# Altium schematic sheet cuihua_test.SchDoc
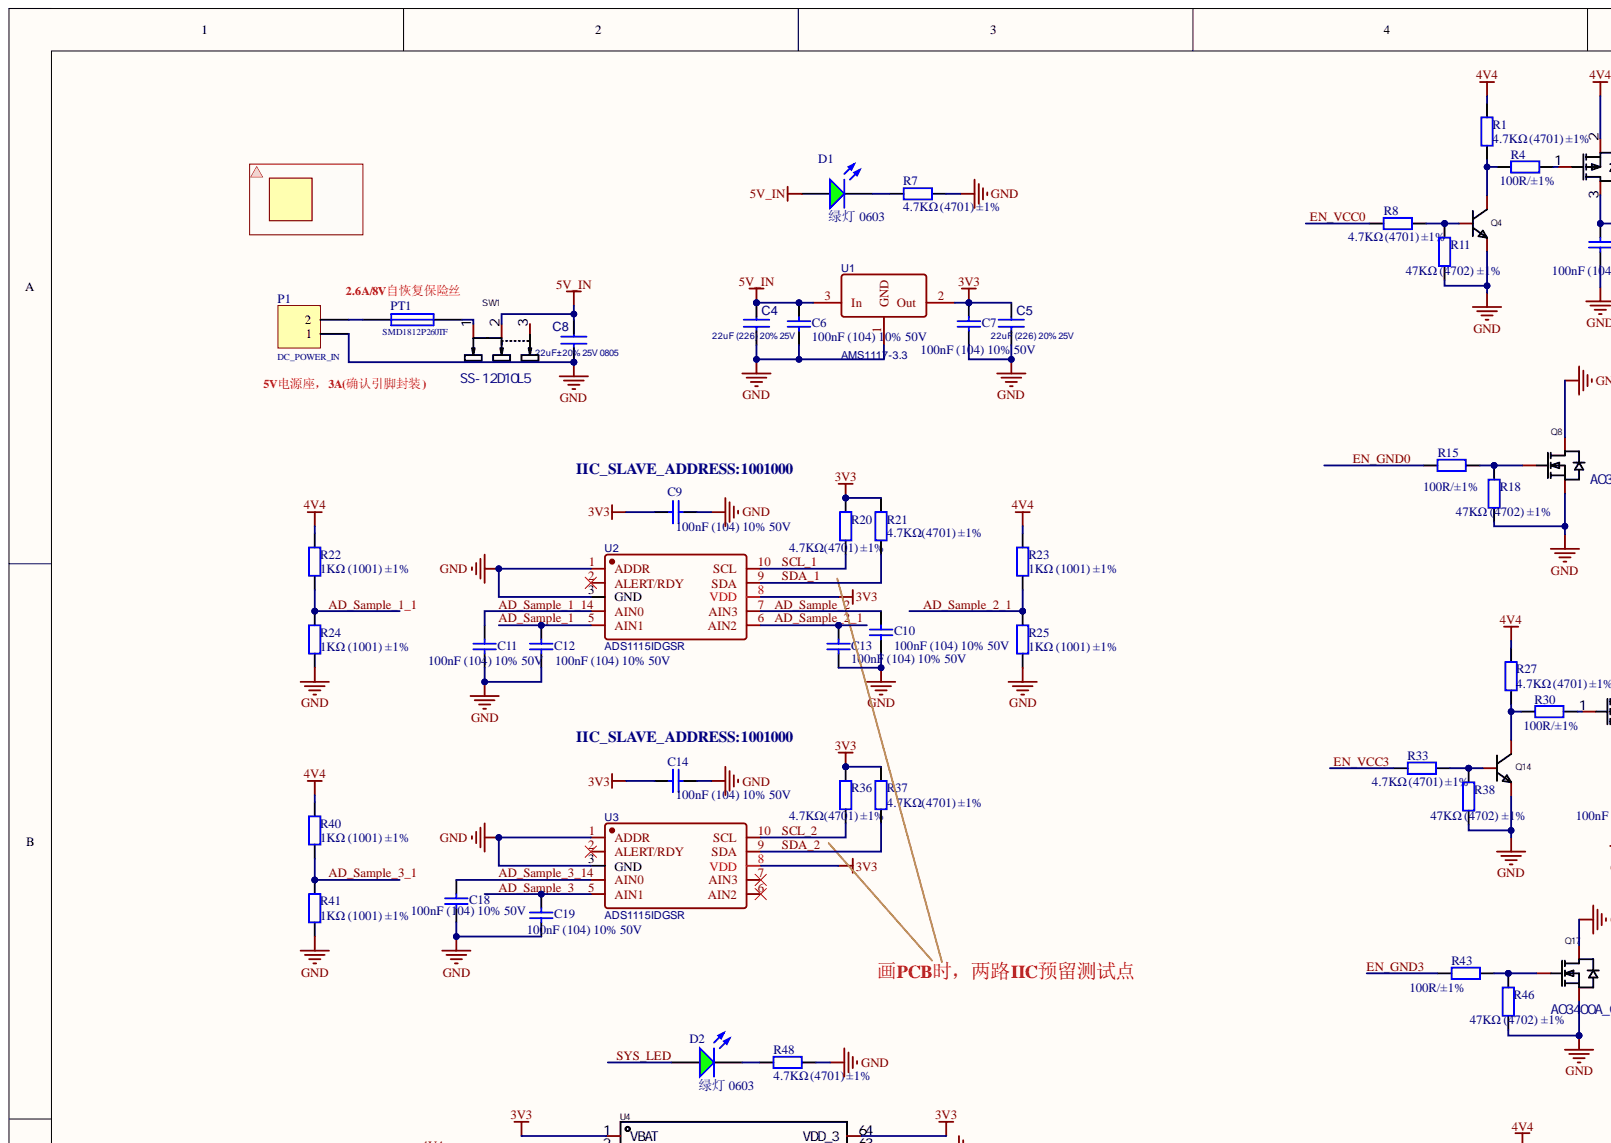
[diagram p0: cuihua_test.SchDoc, top left]
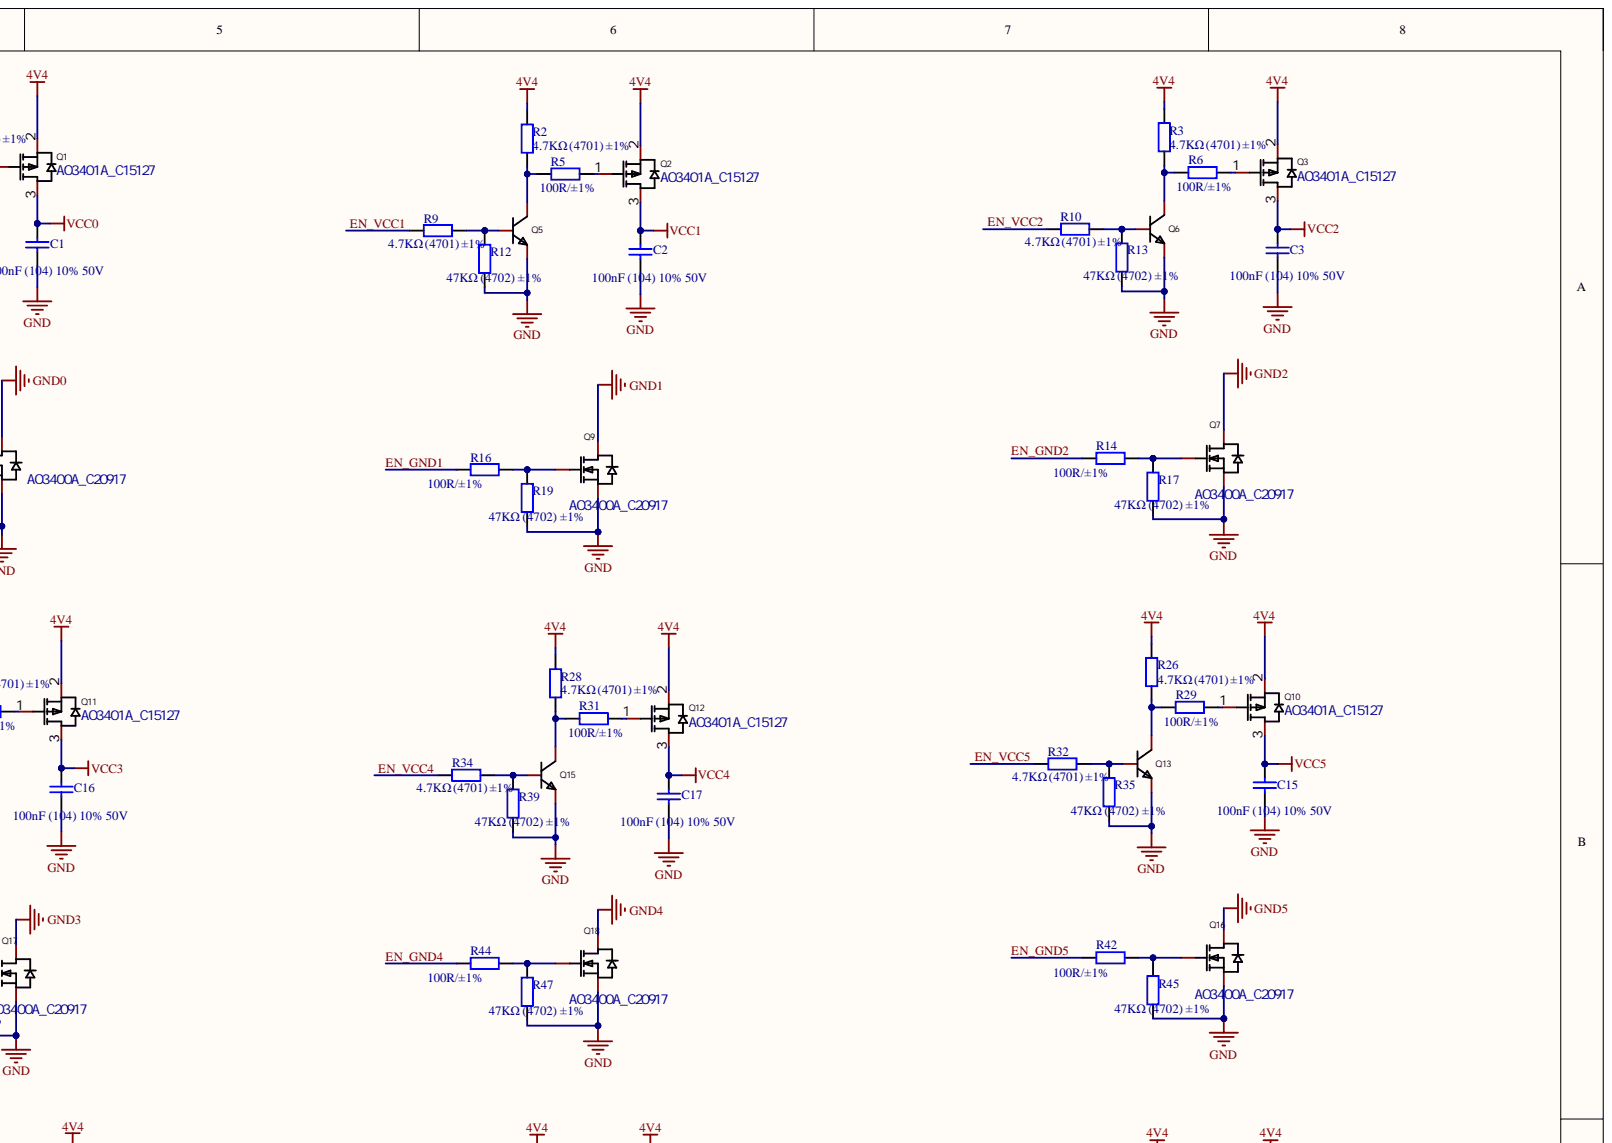
[diagram p1: cuihua_test.SchDoc, top right]
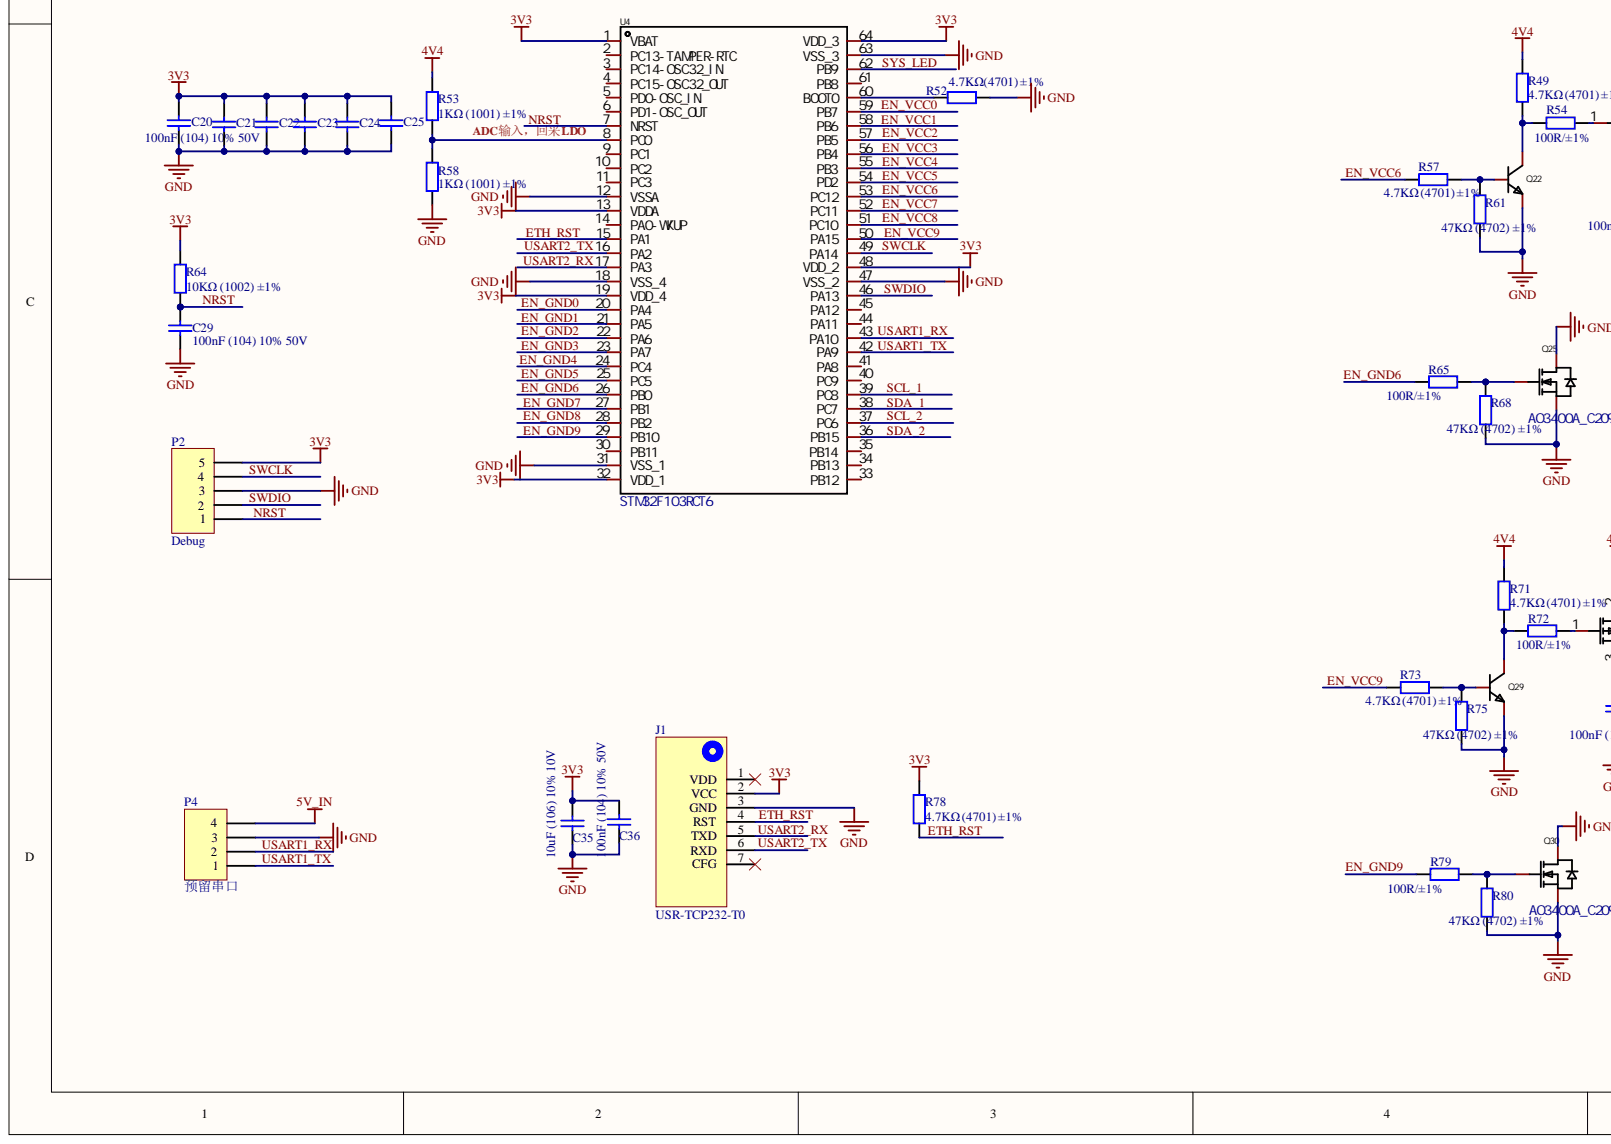
[diagram p2: cuihua_test.SchDoc, bottom left]
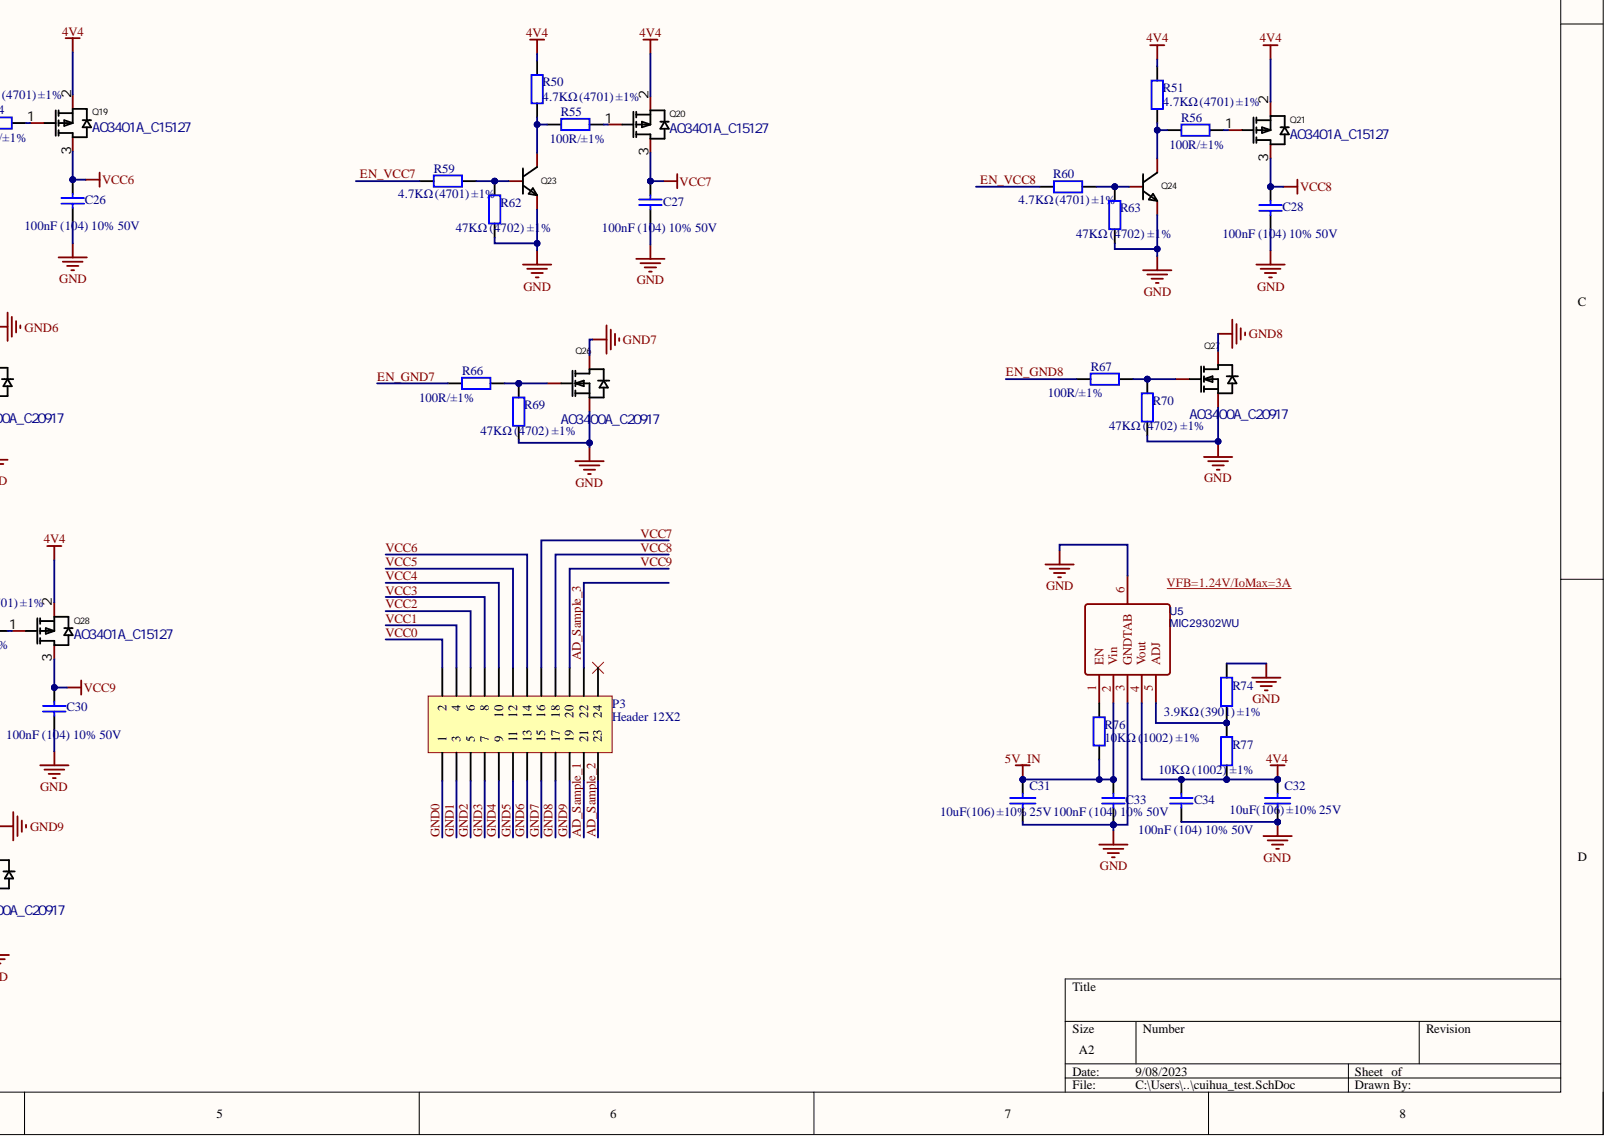
[diagram p3: cuihua_test.SchDoc, bottom right]

## Parts
C1  100nF (104) 10% 50V  [0603_C]
C2  100nF (104) 10% 50V  [0603_C]
C3  100nF (104) 10% 50V  [0603_C]
C4  22uF (226) 20% 25V  [0603_C]
C5  22uF (226) 20% 25V  [0603_C]
C6  100nF (104) 10% 50V  [0603_C]
C7  100nF (104) 10% 50V  [0603_C]
C8  22uF±20% 25V 0805  [0603_C]
C9  100nF (104) 10% 50V  [0603_C]
C10  100nF (104) 10% 50V  [0603_C]
C11  100nF (104) 10% 50V  [0603_C]
C12  100nF (104) 10% 50V  [0603_C]
C13  100nF (104) 10% 50V  [0603_C]
C14  100nF (104) 10% 50V  [0603_C]
C15  100nF (104) 10% 50V  [0603_C]
C16  100nF (104) 10% 50V  [0603_C]
C17  100nF (104) 10% 50V  [0603_C]
C18  100nF (104) 10% 50V  [0603_C]
C19  100nF (104) 10% 50V  [0603_C]
C20  100nF (104) 10% 50V  [0603_C]
C21  100nF (104) 10% 50V  [0603_C]
C22  100nF (104) 10% 50V  [0603_C]
C23  100nF (104) 10% 50V  [0603_C]
C24  100nF (104) 10% 50V  [0603_C]
C25  100nF (104) 10% 50V  [0603_C]
C26  100nF (104) 10% 50V  [0603_C]
C27  100nF (104) 10% 50V  [0603_C]
C28  100nF (104) 10% 50V  [0603_C]
C29  100nF (104) 10% 50V  [0603_C]
C30  100nF (104) 10% 50V  [0603_C]
C31  10uF(106) ±10% 25V  [0805_C]
C32  10uF(106) ±10% 25V  [0805_C]
C33  100nF (104) 10% 50V  [0603_C]
C34  100nF (104) 10% 50V  [0603_C]
C35  10uF (106) 10% 10V  [0603_C]
C36  100nF (104) 10% 50V  [0603_C]
D1  绿灯 0603  Package: 0603  [0603_LED]
D2  绿灯 0603  Package: 0603  [0603_LED]
J1  USR-TCP232-T0  [USR-TCP232-T0]
P1  DC_POWER_IN  [DC-005]
P2  Debug  [HDR1X5]
P3  Header 12X2  [Pin HDR2X12/2.54mm-S]
P4  预留串口  [HDR1X4]
PT1  SMD1812P260TF  [F1812]
Q1  AO3401A_C15127  [SOT-23-3L]
Q2  AO3401A_C15127  [SOT-23-3L]
Q3  AO3401A_C15127  [SOT-23-3L]
Q4  MMBT3904LT1G  [SOT-23-3L]  (value not drawn)
Q5  MMBT3904LT1G  [SOT-23-3L]  (value not drawn)
Q6  MMBT3904LT1G  [SOT-23-3L]  (value not drawn)
Q7  AO3400A_C20917  [SOT-23-3L]
Q8  AO3400A_C20917  [SOT-23-3L]
Q9  AO3400A_C20917  [SOT-23-3L]
Q10  AO3401A_C15127  [SOT-23-3L]
Q11  AO3401A_C15127  [SOT-23-3L]
Q12  AO3401A_C15127  [SOT-23-3L]
Q13  MMBT3904LT1G  [SOT-23-3L]  (value not drawn)
Q14  MMBT3904LT1G  [SOT-23-3L]  (value not drawn)
Q15  MMBT3904LT1G  [SOT-23-3L]  (value not drawn)
Q16  AO3400A_C20917  [SOT-23-3L]
Q17  AO3400A_C20917  [SOT-23-3L]
Q18  AO3400A_C20917  [SOT-23-3L]
Q19  AO3401A_C15127  [SOT-23-3L]
Q20  AO3401A_C15127  [SOT-23-3L]
Q21  AO3401A_C15127  [SOT-23-3L]
Q22  MMBT3904LT1G  [SOT-23-3L]  (value not drawn)
Q23  MMBT3904LT1G  [SOT-23-3L]  (value not drawn)
Q24  MMBT3904LT1G  [SOT-23-3L]  (value not drawn)
Q25  AO3400A_C20917  [SOT-23-3L]
Q26  AO3400A_C20917  [SOT-23-3L]
Q27  AO3400A_C20917  [SOT-23-3L]
Q28  AO3401A_C15127  [SOT-23-3L]
Q29  MMBT3904LT1G  [SOT-23-3L]  (value not drawn)
Q30  AO3400A_C20917  [SOT-23-3L]
R1  4.7KΩ (4701) ±1%  [0603_R]
R2  4.7KΩ (4701) ±1%  [0603_R]
R3  4.7KΩ (4701) ±1%  [0603_R]
R4  100R/±1%  [0603_R]
R5  100R/±1%  [0603_R]
R6  100R/±1%  [0603_R]
R7  4.7KΩ (4701) ±1%  [0603_R]
R8  4.7KΩ (4701) ±1%  [0603_R]
R9  4.7KΩ (4701) ±1%  [0603_R]
R10  4.7KΩ (4701) ±1%  [0603_R]
R11  47KΩ (4702) ±1%  [0603_R]
R12  47KΩ (4702) ±1%  [0603_R]
R13  47KΩ (4702) ±1%  [0603_R]
R14  100R/±1%  [0603_R]
R15  100R/±1%  [0603_R]
R16  100R/±1%  [0603_R]
R17  47KΩ (4702) ±1%  [0603_R]
R18  47KΩ (4702) ±1%  [0603_R]
R19  47KΩ (4702) ±1%  [0603_R]
R20  4.7KΩ(4701) ±1%  [0603_R]
R21  4.7KΩ(4701) ±1%  [0603_R]
R22  1KΩ (1001) ±1%  [0603_R]
R23  1KΩ (1001) ±1%  [0603_R]
R24  1KΩ (1001) ±1%  [0603_R]
R25  1KΩ (1001) ±1%  [0603_R]
R26  4.7KΩ (4701) ±1%  [0603_R]
R27  4.7KΩ (4701) ±1%  [0603_R]
R28  4.7KΩ (4701) ±1%  [0603_R]
R29  100R/±1%  [0603_R]
R30  100R/±1%  [0603_R]
R31  100R/±1%  [0603_R]
R32  4.7KΩ (4701) ±1%  [0603_R]
R33  4.7KΩ (4701) ±1%  [0603_R]
R34  4.7KΩ (4701) ±1%  [0603_R]
R35  47KΩ (4702) ±1%  [0603_R]
R36  4.7KΩ(4701) ±1%  [0603_R]
R37  4.7KΩ(4701) ±1%  [0603_R]
R38  47KΩ (4702) ±1%  [0603_R]
R39  47KΩ (4702) ±1%  [0603_R]
R40  1KΩ (1001) ±1%  [0603_R]
R41  1KΩ (1001) ±1%  [0603_R]
R42  100R/±1%  [0603_R]
R43  100R/±1%  [0603_R]
R44  100R/±1%  [0603_R]
R45  47KΩ (4702) ±1%  [0603_R]
R46  47KΩ (4702) ±1%  [0603_R]
R47  47KΩ (4702) ±1%  [0603_R]
R48  4.7KΩ (4701) ±1%  [0603_R]
R49  4.7KΩ (4701) ±1%  [0603_R]
R50  4.7KΩ (4701) ±1%  [0603_R]
R51  4.7KΩ (4701) ±1%  [0603_R]
R52  4.7KΩ(4701) ±1%  [0603_R]
R53  1KΩ (1001) ±1%  [0603_R]
R54  100R/±1%  [0603_R]
R55  100R/±1%  [0603_R]
R56  100R/±1%  [0603_R]
R57  4.7KΩ (4701) ±1%  [0603_R]
R58  1KΩ (1001) ±1%  [0603_R]
R59  4.7KΩ (4701) ±1%  [0603_R]
R60  4.7KΩ (4701) ±1%  [0603_R]
R61  47KΩ (4702) ±1%  [0603_R]
R62  47KΩ (4702) ±1%  [0603_R]
R63  47KΩ (4702) ±1%  [0603_R]
R64  10KΩ (1002) ±1%  [0603_R]
R65  100R/±1%  [0603_R]
R66  100R/±1%  [0603_R]
R67  100R/±1%  [0603_R]
R68  47KΩ (4702) ±1%  [0603_R]
R69  47KΩ (4702) ±1%  [0603_R]
R70  47KΩ (4702) ±1%  [0603_R]
R71  4.7KΩ (4701) ±1%  [0603_R]
R72  100R/±1%  [0603_R]
R73  4.7KΩ (4701) ±1%  [0603_R]
R74  3.9KΩ (3901) ±1%  [0603_R]
R75  47KΩ (4702) ±1%  [0603_R]
R76  10KΩ (1002) ±1%  [0603_R]
R77  10KΩ (1002) ±1%  [0603_R]
R78  4.7KΩ (4701) ±1%  [0603_R]
R79  100R/±1%  [0603_R]
R80  47KΩ (4702) ±1%  [0603_R]
SW1  SS-12D10L5  [SW-TH_SS-12D10L5]
U1  AMS1117-3.3  [SOT-223]
U2  ADS1115IDGSR  [MSOP-10]
U3  ADS1115IDGSR  [MSOP-10]
U4  STM32F103RCT6  [LQFP-64]
U5  MIC29302WU  [TO-263-5]

## Nets
3V3: C5.1 C7.2 C9.1 C14.1 C20.2 C21.2 C22.2 C23.2 C24.2 C25.2 C35.2 C36.2 J1.2(VCC) P2.5 R20.1 R21.2 R36.1 R37.2 R64.2 R78.1 U1.2 U2.8(VDD) U3.8(VDD) U4.1(VBAT) U4.13(VDDA) U4.19(VDD_4) U4.32(VDD_1) U4.48(VDD_2) U4.64(VDD_3)
4V4: C32.1 C34.1 Q1.2 Q2.2 Q3.2 Q10.2 Q11.2 Q12.2 Q19.2 Q20.2 Q21.2 Q28.2 R1.1 R2.1 R3.1 R22.2 R23.2 R26.1 R27.1 R28.1 R40.2 R49.1 R50.1 R51.1 R53.2 R71.1 R77.2 U5.4(Vout)
5V_IN: C4.1 C6.2 C8.1 C31.1 C33.1 D1.A P4.4 R76.1 SW1.2 U1.3 U5.2(Vin)
GND: C1.1 C2.1 C3.1 C4.2 C5.2 C6.1 C7.1 C8.2 C9.2 C10.1 C11.1 C12.1 C13.1 C14.2 C15.1 C16.1 C17.1 C18.1 C19.1 C20.1 C21.1 C22.1 C23.1 C24.1 C25.1 C26.1 C27.1 C28.1 C29.1 C30.1 C31.2 C32.2 C33.2 C34.2 C35.1 C36.1 J1.3(GND) P1.1 P2.3 P4.3 Q4.2 Q5.2 Q6.2 Q7.2 Q8.2 Q9.2 Q13.2 Q14.2 Q15.2 Q16.2 Q17.2 Q18.2 Q22.2 Q23.2 Q24.2 Q25.2 Q26.2 Q27.2 Q29.2 Q30.2 R7.1 R11.2 R12.2 R13.2 R17.2 R18.2 R19.2 R24.2 R25.2 R35.2 R38.2 R39.2 R41.2 R45.2 R46.2 R47.2 R48.1 R52.2 R58.2 R61.2 R62.2 R63.2 R68.2 R69.2 R70.2 R74.1 R75.2 R80.2 U1.1 U2.1(ADDR) U2.3(GND) U3.1(ADDR) U3.3(GND) U4.12(VSSA) U4.18(VSS_4) U4.31(VSS_1) U4.47(VSS_2) U4.63(VSS_3) U5.3(GND) U5.6(TAB)
AD_Sample_1: C12.2 P3.21 U2.5(AIN1)
AD_Sample_1_1: C11.2 R22.1 R24.1 U2.4(AIN0)
AD_Sample_2: C10.2 P3.23 U2.7(AIN3)
AD_Sample_2_1: C13.2 R23.1 R25.1 U2.6(AIN2)
AD_Sample_3: C19.2 P3.22 U3.5(AIN1)
AD_Sample_3_1: C18.2 R40.1 R41.1 U3.4(AIN0)
EN_GND0: R15.2 U4.20(PA4)
EN_GND1: R16.2 U4.21(PA5)
EN_GND2: R14.2 U4.22(PA6)
EN_GND3: R43.2 U4.23(PA7)
EN_GND4: R44.2 U4.24(PC4)
EN_GND5: R42.2 U4.25(PC5)
EN_GND6: R65.2 U4.26(PB0)
EN_GND7: R66.2 U4.27(PB1)
EN_GND8: R67.2 U4.28(PB2)
EN_GND9: R79.2 U4.29(PB10)
EN_VCC0: R8.2 U4.59(PB7)
EN_VCC1: R9.2 U4.58(PB6)
EN_VCC2: R10.2 U4.57(PB5)
EN_VCC3: R33.2 U4.56(PB4)
EN_VCC4: R34.2 U4.55(PB3)
EN_VCC5: R32.2 U4.54(PD2)
EN_VCC6: R57.2 U4.53(PC12)
EN_VCC7: R59.2 U4.52(PC11)
EN_VCC8: R60.2 U4.51(PC10)
EN_VCC9: R73.2 U4.50(PA15)
ETH_RST: J1.4(RST) R78.2 U4.15(PA1)
GND0: P3.1 Q8.3
GND1: P3.3 Q9.3
GND2: P3.5 Q7.3
GND3: P3.7 Q17.3
GND4: P3.9 Q18.3
GND5: P3.11 Q16.3
GND6: P3.13 Q25.3
GND7: P3.15 Q26.3
GND8: P3.17 Q27.3
GND9: P3.19 Q30.3
NRST: C29.2 P2.1 R64.1 U4.7(NRST)
SCL_1: R20.2 U2.10(SCL) U4.39(PC8)
SCL_2: R36.2 U3.10(SCL) U4.37(PC6)
SDA_1: R21.1 U2.9(SDA) U4.38(PC7)
SDA_2: R37.1 U3.9(SDA) U4.36(PB15)
SWCLK: P2.4 U4.49(PA14)
SWDIO: P2.2 U4.46(PA13)
SYS_LED: D2.A U4.62(PB9)
USART1_RX: P4.2 U4.43(PA10)
USART1_TX: P4.1 U4.42(PA9)
USART2_RX: J1.5(TXD) U4.17(PA3)
USART2_TX: J1.6(RXD) U4.16(PA2)
VCC0: C1.2 P3.2 Q1.3
VCC1: C2.2 P3.4 Q2.3
VCC2: C3.2 P3.6 Q3.3
VCC3: C16.2 P3.8 Q11.3
VCC4: C17.2 P3.10 Q12.3
VCC5: C15.2 P3.12 Q10.3
VCC6: C26.2 P3.14 Q19.3
VCC7: C27.2 P3.16 Q20.3
VCC8: C28.2 P3.18 Q21.3
VCC9: C30.2 P3.20 Q28.3
NetD1_K: D1.K R7.2
NetD2_K: D2.K R48.2
NetP1_2: P1.2 PT1.1
NetPT1_2: PT1.2 SW1.1
NetQ1_1: Q1.1 R4.1
NetQ2_1: Q2.1 R5.1
NetQ3_1: Q3.1 R6.1
NetQ4_1: Q4.1 R8.1 R11.1
NetQ4_3: Q4.3 R1.2 R4.2
NetQ5_1: Q5.1 R9.1 R12.1
NetQ5_3: Q5.3 R2.2 R5.2
NetQ6_1: Q6.1 R10.1 R13.1
NetQ6_3: Q6.3 R3.2 R6.2
NetQ7_1: Q7.1 R14.1 R17.1
NetQ8_1: Q8.1 R15.1 R18.1
NetQ9_1: Q9.1 R16.1 R19.1
NetQ10_1: Q10.1 R29.1
NetQ11_1: Q11.1 R30.1
NetQ12_1: Q12.1 R31.1
NetQ13_1: Q13.1 R32.1 R35.1
NetQ13_3: Q13.3 R26.2 R29.2
NetQ14_1: Q14.1 R33.1 R38.1
NetQ14_3: Q14.3 R27.2 R30.2
NetQ15_1: Q15.1 R34.1 R39.1
NetQ15_3: Q15.3 R28.2 R31.2
NetQ16_1: Q16.1 R42.1 R45.1
NetQ17_1: Q17.1 R43.1 R46.1
NetQ18_1: Q18.1 R44.1 R47.1
NetQ19_1: Q19.1 R54.1
NetQ20_1: Q20.1 R55.1
NetQ21_1: Q21.1 R56.1
NetQ22_1: Q22.1 R57.1 R61.1
NetQ22_3: Q22.3 R49.2 R54.2
NetQ23_1: Q23.1 R59.1 R62.1
NetQ23_3: Q23.3 R50.2 R55.2
NetQ24_1: Q24.1 R60.1 R63.1
NetQ24_3: Q24.3 R51.2 R56.2
NetQ25_1: Q25.1 R65.1 R68.1
NetQ26_1: Q26.1 R66.1 R69.1
NetQ27_1: Q27.1 R67.1 R70.1
NetQ28_1: Q28.1 R72.1
NetQ29_1: Q29.1 R73.1 R75.1
NetQ29_3: Q29.3 R71.2 R72.2
NetQ30_1: Q30.1 R79.1 R80.1
NetR52_1: R52.1 U4.60(BOOT0)
NetR53_1: R53.1 R58.1 U4.8(PC0)
NetR74_2: R74.2 R77.1 U5.5(ADJ)
NetR76_2: R76.2 U5.1(EN)

Not connected: J1 1(VDD) 7(CFG); P3 24; SW1 3; U2 2(ALERT/RDY); U3 2(ALERT/RDY) 6(AIN2) 7(AIN3); U4 2(PC13-TAMPER-RTC) 3(PC14-OSC32_IN) 4(PC15-OSC32_OUT) 5(PD0-OSC_IN) 6(PD1-OSC_OUT) 9(PC1) 10(PC2) 11(PC3) 14(PA0-WKUP) 30(PB11) 33(PB12) 34(PB13) 35(PB14) 40(PC9) 41(PA8) 44(PA11) 45(PA12) 61(PB8)

## Library data of the parts
C1-C3 C6 C7 C9-C30 C33 C34 C36 = 0603 100nF (104) 10% 50V: 贴片电容; Component Kind: Standard; ComponentLink1Description: 供应商链接; ComponentLink1URL: http://www.szlcsc.com/product/details_15331.html; Package: 0603; Supplier: LC; SuppliersPartNumber: C14663
  C1-C3 C6 C7 C9-C30: Library Name: Cap.SchLib
  C33 C34 C36: Library Name: Miscellaneous Devices LC.IntLib
C4 C5 = CAPACITOR NON-POL_Dup2: CL31A106KBHNNNE; Component Kind: Standard
C8 = 22uF±20% 25V 0805: 贴片电容; Component Kind: Standard; Library Name: cuihua_test.SchLib
C31 C32 = CAP: Capacitor; Component Kind: Standard
C35 = 0603 10uF (106) 10% 10V: 贴片电容; Component Kind: Standard; ComponentLink1Description: 供应商链接; ComponentLink1URL: http://www.szlcsc.com/product/details_20411.html; Library Name: Miscellaneous Devices LC.IntLib; Package: 0603; Supplier: LC; SuppliersPartNumber: C19702
D1 D2 = 0603 绿灯: 发光二极管; Component Kind: Standard; ComponentLink1Description: 供应商链接; ComponentLink1URL: http://www.szlcsc.com/product/details_73152.html; Library Name: Miscellaneous Devices LC.IntLib; Supplier: LC; SuppliersPartNumber: C72043
J1 = USR-TCP232-T: 山东有人 联网模块https://www.usr.cn/Download/1193.html; Component Kind: Standard
P1 = Header 2: Header, 2-Pin; Component Kind: Standard; LatestRevisionDate: 17-Jul-2002; LatestRevisionNote: Re-released for DXP Platform.; Library Name: Miscellaneous Connectors.IntLib; Signal Integrity: Connector; Publisher: Altium Limited
P2 = Header 5: Header, 5-Pin; Component Kind: Standard; LatestRevisionDate: 17-Jul-2002; LatestRevisionNote: Re-released for DXP Platform.; Library Name: Miscellaneous Connectors.IntLib; Signal Integrity: Connector; Publisher: Altium Limited
P3 = Header 12X2: Header, 12-Pin, Dual row; Component Kind: Standard; LatestRevisionDate: 17-Jul-2002; LatestRevisionNote: Re-released for DXP Platform.; Library Name: cuihua_test.SchLib; Publisher: Altium Limited
P4 = Header 4: Header, 4-Pin; Component Kind: Standard; LatestRevisionDate: 17-Jul-2002; LatestRevisionNote: Re-released for DXP Platform.; Library Name: Miscellaneous Connectors.IntLib; Signal Integrity: Connector; Publisher: Altium Limited
PT1 = FUSE1: Fuse; Component Kind: Standard
Q1-Q3 Q10-Q12 Q19-Q21 Q28 = AO3401A_C15127: Manufacturer: AOS; Add into BOM: yes; Continuous Drain Current (Id): 4A; Drain Source On Resistance (RDS(on)@Vgs,Id): 44mΩ@10V,4.3A; Drain Source Voltage (Vdss): 30V; Gate Threshold Voltage (Vgs(th)@Id): 1.3V@250uA; Power Dissipation (Pd): 1.4W; Type: P沟道; Component Kind: Standard; Convert to PCB: yes; Datasheet: https://atta.szlcsc.com/upload/public/pdf/source/20160507/1462613609053.pdf; Device: AO3401A_C15127; JLCPCB Part Class: Basic Part; LCSC Part Name: P沟道 30V 4A; Manufacturer Part: AO3401A; Name: AO3401A; PCB3D: SOT-23-3P_L2.9-W1.3-H1.0-LS2.4-P0.95; Supplier: LCSC; Supplier Footprint: SOT-23-3L; Supplier Part: C15127; Symbol: AO3401A
Q4-Q6 Q13-Q15 Q22-Q24 Q29 = MMBT3904LT1G: NPN 耐压:40V 电流:200mA NPN 双极晶体管（C81464）; Manufacturer: onsemi(安森美); Add into BOM: yes; Collector Current (Ic): 200mA; Collector-Emitter Breakdown Voltage (Vceo): 40V; Collector-Emitter Saturation Voltage (VCE(sat)@Ic,Ib): 300mV@50mA,5mA; DC Current Gain (hFE@Ic,Vce): 100@10mA,1V; Power Dissipation (Pd): 300mW; Transition Frequency (fT): 300MHz; Collector Cut-Off Current (Icbo): -; Component Kind: Standard; Convert to PCB: yes; Datasheet: https://atta.szlcsc.com/upload/public/pdf/source/20170413/1492062904001.PDF; Device: MMBT3904LT1G; JLCPCB Part Class: Extended Part; LCSC Part Name: NPN 40V 200mA NPN 双极晶体管; Manufacturer Part: MMBT3904LT1G; Name: MMBT3904LT1G; Operating Temperature: -55℃~+150℃@(Tj); Supplier: LCSC; Supplier Footprint: SOT-23; Supplier Part: C81464; Symbol: MMBT3904LT1G; Transistor Type: NPN; Library Name: cuihua_test.SchLib
Q7-Q9 Q16-Q18 Q25-Q27 Q30 = AO3400A_C20917: Manufacturer: AOS; Add into BOM: yes; Continuous Drain Current (Id): 5.7A; Drain Source On Resistance (RDS(on)@Vgs,Id): 26.5mΩ@10V,5.7A; Drain Source Voltage (Vdss): 30V; Gate Threshold Voltage (Vgs(th)@Id): 1.5V@250uA; Power Dissipation (Pd): 1.4W; Type: N沟道; Component Kind: Standard; Convert to PCB: yes; Datasheet: https://atta.szlcsc.com/upload/public/pdf/source/20140107/1457706668533.pdf; Device: AO3400A_C20917; JLCPCB Part Class: Basic Part; LCSC Part Name: N沟道 30V 5.7A; Manufacturer Part: AO3400A; Name: AO3400A; PCB3D: SOT-23-3L_L2.9-W1.6-H1.1-LS2.8-P0.95; Supplier: LCSC; Supplier Footprint: SOT-23-3L; Supplier Part: C20917; Symbol: AO3400A
R1-R3 R7-R13 R17-R19 R26-R28 R32-R35 R38 R39 R45-R51 R57 R59-R63 R68-R71 R73 R75 R78 R80 = 0402 1KΩ (1001) 1%: 贴片电阻; Component Kind: Standard; ComponentLink1Description: 供应商链接; ComponentLink1URL: http://www.szlcsc.com/product/details_12256.html; Library Name: Miscellaneous Devices LC.IntLib; Package: 0402; Supplier: LC; SuppliersPartNumber: C11702
R4-R6 R14-R16 R29-R31 R42-R44 R54-R56 R65-R67 R72 R79 = 0603 1.5KΩ (1501) 1%: 贴片电阻; Component Kind: Standard; ComponentLink1Description: 供应商链接; ComponentLink1URL: http://www.szlcsc.com/product/details_23570.html; Library Name: Miscellaneous Devices LC.IntLib; Package: 0603; Supplier: LC; SuppliersPartNumber: C22843
R20-R25 R36 R37 R40 R41 R52 R53 R58 R64 R74 R76 R77 = 0603 10KΩ (1002) 1%: 贴片电阻; Component Kind: Standard; ComponentLink1Description: 供应商链接; ComponentLink1URL: http://www.szlcsc.com/product/details_26547.html; Package: 0603; Supplier: LC; SuppliersPartNumber: C25804
  R20-R25 R36 R37 R40 R41 R52 R53 R58 R74 R76 R77: Library Name: 64ChannleTest_GAS.SCHLIB
  R64: Library Name: Instrument.SCHLIB
SW1 = SS-12D10L5: 滑动开关; Manufacturer: XKB Connectivity(中国星坤); Actuator Color: 黑色; Actuator Style: 矩形柱状; Add into BOM: yes; Circuit: 单刀双掷; Current Rating (AC): 3A; Mechanical Life: 1万次; Pin Style: PC引脚; Switch Height: 6.4mm; Switch Length: 13mm; Switch Width: 6.8mm; Voltage Rating (AC): 125V; Component Kind: Standard; Convert to PCB: yes; Current Rating (DC): 3A; Datasheet: https://atta.szlcsc.com/upload/public/pdf/source/20211009/D6412F37AABE6F9459A6EE7FA4DAEADB.pdf; Device: SS-12D10L5; JLCPCB Part Class: Extended Part; LCSC Part Name: 柄长5mm 直插双档 滑动开关 大电流; Manufacturer Part: SS-12D10L5; Mounting Style: 直插; Name: SS-12D10L5; Operating Temperature: -40℃~+85℃; Supplier: LCSC; Supplier Footprint: 插件; Supplier Part: C319012; Symbol: SS-12D10L5; Voltage Rating (DC): 125V; Library Name: cuihua_test.SchLib
U1 = AMS1117-3.3: 输入18V 输出3.3V 1A （C347222）; BOM_Manufacturer: SK; BOM_Manufacturer Part: AMS1117-5.0; Contributor: LCSC; SMT Type: Extend; BOM_LCSC Assembly: Yes; BOM_Supplier: LCSC; BOM_Supplier Part: C165483; Component Kind: Standard; spicePre: U; spiceSymbolName: AMS1117-5.0_C165483; Library Name: cuihua_test.SchLib
U2 U3 = ADS1115IDGSR: 模数转换芯片ADC（C37593）; BOM_Manufacturer: TI; SMT Type: Extend; BOM_JLCPCB SMD: Yes; BOM_Manufacturer Part: ADS1115IDGSR; BOM_Supplier: LCSC; BOM_Supplier Part: C37593; Component Kind: Standard; image: //image.lceda.cn/szlcsc/C37593.jpg; spicePre: U; spiceSymbolName: ADS1115IDGSR; Library Name: cuihua_test.SchLib
U4 = STM32F103RCT6: MCU; Manufacturer: ST(意法半导体); ADC (Bit): 12bit; Add into BOM: yes; CPU Core: ARM Cortex-M3; CPU Maximum Speed: 72MHz; Direct Memory Access: 有; EEPROM: 256KB; GPIO Ports Number: 51; Operating Voltage Range: 2V~3.6V; Peripheral/Function: DMA；看门狗；片载温度传感器；PWM; Program Memory Type: FLASH; RAM Size: 48KB; CCP Capture/Compare: -; communication protocol: -; Component Kind: Standard; Convert to PCB: yes; DAC (Bit): 12bit; Datasheet: https://atta.szlcsc.com/upload/public/pdf/source/20161130/1480498797345.pdf; Device: STM32F103RCT6; Infrared Data Association: 有; Internal Comparator: -; Internal Oscillator: 有; JLCPCB Part Class: Extended Part; LCSC Part Name: ARM Cortex-M3 72MHz; Low-Voltage Detect: 有; Manufacturer Part: STM32F103RCT6; Name: STM32F103RCT6; Operating Temperature Range: 40℃~+85℃; Program Storage Size: 256KB; PWM (Bit): -; Real-Time Clock: -; Secure Digital Input and Output: -; Supplier: LCSC; Supplier Footprint: LQFP-64(10x10); Supplier Part: C8323; Symbol: STM32F103RCT6; Universal Serial Bus: 有; Watchdog: 有; Library Name: cuihua_test.SchLib
U5 = MIC29302WU: 3A Fast-Response LDO Regulator（C2995533）; BOM_Manufacturer: MICROCHIP; SMT Type: Extend; BOM_LCSC Assembly: Yes; BOM_Manufacturer Part: MIC29302WU; BOM_Supplier: LCSC; BOM_Supplier Part: C11149; Component Kind: Standard; image: //image.lceda.cn/szlcsc/C11149.jpg; spicePre: U; spiceSymbolName: MIC29302WU; Library Name: cuihua_test.SchLib
